# Altium schematic sheet 1_Interface_Charge_RBF.SchDoc
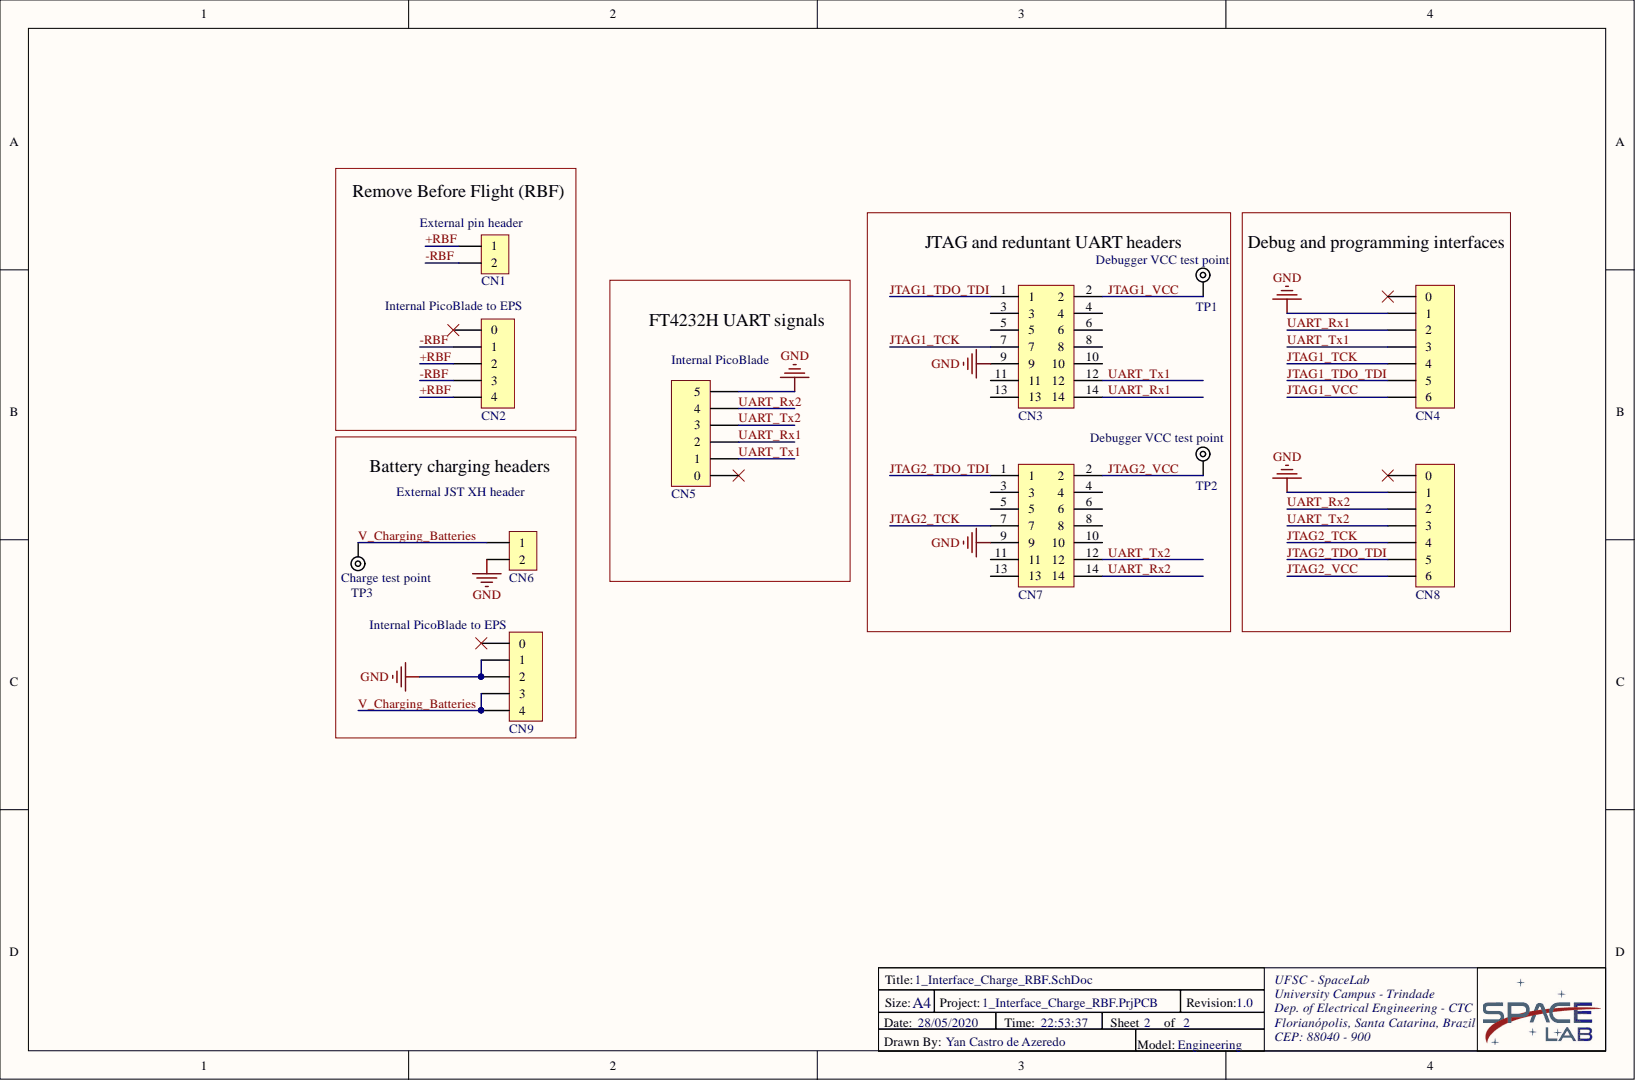
[diagram p0: 1_Interface_Charge_RBF.SchDoc]

## Parts
CN1  M20-9990245  [CONN HEADER VERT 2POS 2.54mm]  (value not drawn)
CN2  0533980471  [PICO BLADE 0533980471]  (value not drawn)
CN3  M20-9980745  [CONN HEADER VERT 14POS 2.54MM]  (value not drawn)
CN4  53398-0671  [PICO BLADE 0533980671]  (value not drawn)
CN5  53398-0571  [PICO BLADE 0533980571]  (value not drawn)
CN6  B2B-XH-A-M(LF)(SN)  [B2B-XH-A-M(LF)(SN)]  (value not drawn)
CN7  M20-9980745  [CONN HEADER VERT 14POS 2.54MM]  (value not drawn)
CN8  53398-0671  [PICO BLADE 0533980671]  (value not drawn)
CN9  0533980471  [PICO BLADE 0533980471]  (value not drawn)
TP1  Debugger VCC test point  [TEST POINT PTH]  (no BOM)
TP2  Debugger VCC test point  [TEST POINT PTH]  (no BOM)
TP3  Charge test point  [TEST POINT PTH]  (no BOM)

## Nets
GND: CN3.9 CN4.1 CN5.5 CN6.2 CN7.9 CN8.1 CN9.1 CN9.2
+RBF: CN1.1 CN2.2 CN2.4
-RBF: CN1.2 CN2.1 CN2.3
JTAG1_TCK: CN3.7 CN4.4
JTAG1_TDO_TDI: CN3.1 CN4.5
JTAG1_VCC: CN3.2 CN4.6 TP1.1
JTAG2_TCK: CN7.7 CN8.4
JTAG2_TDO_TDI: CN7.1 CN8.5
JTAG2_VCC: CN7.2 CN8.6 TP2.1
UART_Rx1: CN3.14 CN4.2 CN5.2
UART_Rx2: CN5.4 CN7.14 CN8.2
UART_Tx1: CN3.12 CN4.3 CN5.1
UART_Tx2: CN5.3 CN7.12 CN8.3
V_Charging_Batteries: CN6.1 CN9.3 CN9.4 TP3.1

Not connected: CN2 0; CN3 3 4 5 6 8 10 11 13; CN4 0; CN5 0; CN7 3 4 5 6 8 10 11 13; CN8 0; CN9 0

## Library data of the parts
CN1 = M20-9990245: M20 HDR, PIN, SIL, VERT, 2W; Manufacturer: Harwin; Connector Type: Male Pin; Contact Resistance: 25mR; Current: 3A; Gender: Male; Insulation Resistance: 10GR; Mating Cycles: 300; Number of Contacts: 2; Pitch: 2.54mm; Voltage: 50V; Voltage Rating (AC): 750V; Case/Package: SIL; Color: Black; Component Kind: Standard; ComponentLink1Description: http://www.farnell.com/datasheets/2368211.pdf?src-supplier=Element14; ComponentLink1URL: http://www.farnell.com/datasheets/2368211.pdf?src-supplier=Element14; ComponentLink2Description: http://uk.rs-online.com/webdocs/1388/0900766b81388728.pdf?src-supplier=RSComponents; ComponentLink2URL: http://uk.rs-online.com/webdocs/1388/0900766b81388728.pdf?src-supplier=RSComponents; ComponentLink3Description: https://cdn.harwin.com/pdfs/Harwin_PC_Connectors_M20.pdf?src-supplier=Digi-Key; ComponentLink3URL: https://cdn.harwin.com/pdfs/Harwin_PC_Connectors_M20.pdf?src-supplier=Digi-Key; ComponentLink4Description: http://www.mouser.com/ds/2/181/Harwin_Product_Catalog_page_206-1134556.pdf?src-supplier=Mouser; ComponentLink4URL: http://www.mouser.com/ds/2/181/Harwin_Product_Catalog_page_206-1134556.pdf?src-supplier=Mouser; Contact Material: Brass,Bronze; Contact Mating Length: 0.24inch; Contact Plating: Gold; Current Rating: 3A; Depth: 2.54mm; Height: 0.12inch; Housing Color: Black; Housing Material: Nylon; Lead Pitch: 2.54mm; Length: 5.08mm; Manufacturer Part Number: M20-9990245; Max Current Rating: 3A; Max Operating Temperature: 105°C; Min Operating Temperature: -40°C; Mount: Through Hole; Number of Poles: 2; Number of Positions: 2; Number of Rows: 1; Orientation: Straight; Package Quantity: 1000; Packaging: Bag; Pricing 1: 1=0.15, 10=0.136, 100=0.0841, 500=0.0696, 1000=0.0609, 5000=0.0522, 10000=0.05075 (USD); Pricing 2: 1=0.14, 10=0.083, 100=0.069, 1000=0.06, 2000=0.052, 10000=0.05 (USD); Radiation Hardening: No; REACH SVHC: No SVHC; Stock 1: 27298; Stock 2: 26881; Supplier 1: Digi-Key; Supplier 2: Mouser; Supplier Part Number 1: 952-2261-ND; Supplier Part Number 2: 855-M20-9990245; Termination: Solder; Weight: 0.25lb; Width: 0.25inch; Working Voltage: 750V; Library Name: IB_floripasat2.SchLib
CN2 CN9 = 0533980471: CONN HEADER SMD 4POS 1.25MM; Manufacturer: Molex; N of Positions: 4; Component Kind: Standard; Mount Type: SMD; Pitch: 1.25mm; Value: 0533980471; Library Name: IB_floripasat2.SchLib
CN3 CN7 = M20-9980745: Headers & Wire Housings 07+07 DIL VERTICAL PIN HEADER GOLD HT; Manufacturer: Harwin; Connector Type: Male Pin; Contact Resistance: 25mR; Gender: Male; Max Current Rating: 3A; Number of Contacts: 14; Pitch: 2.54mm; Row Spacing: 0.1inch; Color: Black; Component Kind: Standard; ComponentLink1Description: https://www.verical.com/server-webapp/html/getDatasheet?partID=468550&src-supplier=Verical; ComponentLink1URL: https://www.verical.com/server-webapp/html/getDatasheet?partID=468550&src-supplier=Verical; ComponentLink2Description: http://www.harwin.com/include/downloads/drawings/M20-998.PDF?src-supplier=Element14; ComponentLink2URL: http://www.harwin.com/include/downloads/drawings/M20-998.PDF?src-supplier=Element14; ComponentLink3Description: http://www.mouser.com/ds/2/181/M20-998-351176.pdf?src-supplier=Mouser; ComponentLink3URL: http://www.mouser.com/ds/2/181/M20-998-351176.pdf?src-supplier=Mouser; Contact Material: Brass; Contact Mating Length: 0.24inch; Contact Plating: Gold; Depth: 5.08mm; Housing Color: Black; Housing Material: Nylon; Length: 17.78mm; Manufacturer Part Number: M20-9980745; Max Operating Temperature: 105°C; Min Operating Temperature: -40°C; Mount: Through Hole; Number of Positions: 14; Number of Rows: 2; Orientation: Straight; Package Quantity: 500; Packaging: Bag; Pricing 1: 1=0.54, 10=0.51, 100=0.391, 500=0.34, 1000=0.2805, 5000=0.2465, 10000=0.2295 (USD); Pricing 2: 1=0.54, 10=0.51, 100=0.387, 500=0.34, 1000=0.28, 2500=0.246 (USD); Radiation Hardening: No; REACH SVHC: No SVHC; Stock 1: 15564; Stock 2: 1790; Supplier 1: Digi-Key; Supplier 2: Mouser; Supplier Part Number 1: 952-1932-ND; Supplier Part Number 2: 855-M20-9980745; Termination: Solder; Library Name: IB_floripasat2.SchLib
CN4 CN8 = 53398-0671: 1.25mm Pitch PicoBlade™ Header, Surface Mount, Vertical, 6 Circuits; Manufacturer: Molex; Body Plating: Tin; Contact Resistance: 20mR; Current Rating: 1A; Gender: Male; Glow Wire Compliant: Non-compliant; Insulation Resistance: 100MR; Number of Circuits: 6; Voltage Rating: 125V; Color: Natural; Component Kind: Standard; ComponentLink1Description: https://www.molex.com/pdm_docs/sd/533980671_sd.pdf?src-supplier=Digi-Key; ComponentLink1URL: https://www.molex.com/pdm_docs/sd/533980671_sd.pdf?src-supplier=Digi-Key; Contact Material: Bronze,Tin; Contact Plating: Tin; Flammability Rating: UL94 V-0; Housing Color: Natural; Housing Material: Nylon; Lead Free: Lead Free; Lead Pitch: 1.25mm; Manufacturer Part Number: 53398-0671; Max Operating Temperature: 85°C; Min Operating Temperature: -40°C; Mount: Surface Mount; Number of Contacts: 6; Number of Positions: 6; Number of Rows: 1; Orientation: Vertical; Package Quantity: 1000; Packaging: Tape and Reel; Pitch: 1.25mm; Pricing 1: 1=1.39, 10=1.26, 100=1.0802, 500=0.90014 (USD); Pricing 2: 1=1.15, 10=1.01, 100=0.864, 500=0.727, 1000=0.427, 2000=0.374 (USD); Radiation Hardening: No; REACH SVHC: Unknown; Stock 1: 21086; Stock 2: 26; Supplier 1: Digi-Key; Supplier 2: Mouser; Supplier Part Number 1: WM7610DKR-ND; Supplier Part Number 2: 538-53398-0671; Termination: Solder; Voltage Rating (AC): 125V; Voltage Rating (DC): 125V; Working Voltage: 125V; Library Name: IB_floripasat2.SchLib
CN5 = 53398-0571: Wire-To-Board Connector, Vertical, PicoBlade 53398 Series, Surface Mount, Header, 5, 1.25 mm; Manufacturer: Molex; Body Plating: Tin; Contact Resistance: 20mR; Current Rating: 1A; Gender: Male; Glow Wire Compliant: Non-compliant; Insulation Resistance: 100MR; Max Voltage Rating (AC): 125V; Number of Circuits: 5; Pitch: 1.25mm; Color: Natural; Component Kind: Standard; ComponentLink1Description: http://www.molex.com/webdocs/datasheets/pdf/en-us/0533980571_PCB_HEADERS.pdf?src-supplier=Avnet+Europe; ComponentLink1URL: http://www.molex.com/webdocs/datasheets/pdf/en-us/0533980571_PCB_HEADERS.pdf?src-supplier=Avnet+Europe; ComponentLink2Description: http://www.farnell.com/datasheets/2697810.pdf?src-supplier=Newark; ComponentLink2URL: http://www.farnell.com/datasheets/2697810.pdf?src-supplier=Newark; ComponentLink3Description: https://www.distrelec.biz/Web/Downloads/46/_e/gf116833-116846_e.pdf?mime=application%2Fpdf&src-supplier=Distrelec; ComponentLink3URL: https://www.distrelec.biz/Web/Downloads/46/_e/gf116833-116846_e.pdf?mime=application%2Fpdf&src-supplier=Distrelec; ComponentLink4Description: http://www.farnell.com/datasheets/1632115.pdf?src-supplier=Element14; ComponentLink4URL: http://www.farnell.com/datasheets/1632115.pdf?src-supplier=Element14; ComponentLink5Description: http://uk.rs-online.com/webdocs/078f/0900766b8078fc92.pdf?src-supplier=RSComponents; ComponentLink5URL: http://uk.rs-online.com/webdocs/078f/0900766b8078fc92.pdf?src-supplier=RSComponents; ComponentLink6Description: http://www.farnell.com/datasheets/1867377.pdf?src-supplier=Element14; ComponentLink6URL: http://www.farnell.com/datasheets/1867377.pdf?src-supplier=Element14; ComponentLink7Description: http://www.farnell.com/datasheets/1626108.pdf?src-supplier=Element14; ComponentLink7URL: http://www.farnell.com/datasheets/1626108.pdf?src-supplier=Element14; ComponentLink8Description: http://www.mouser.com/ds/2/276/0533980571_PCB_HEADERS-146486.pdf?src-supplier=Mouser; ComponentLink8URL: http://www.mouser.com/ds/2/276/0533980571_PCB_HEADERS-146486.pdf?src-supplier=Mouser; Contact Gender: Male; Contact Material: Bronze,Tin,Brass; Contact Plating: Tin; Flammability Rating: UL94 V-0; Housing Color: Natural; Housing Material: Nylon; Lead Free: Lead Free; Lead Pitch: 1.25mm; Manufacturer Part Number: 53398-0571; Max Operating Temperature: 85°C; Min Operating Temperature: -40°C; Mount: Surface Mount; Number of Contacts: 5; Number of Positions: 5; Number of Rows: 1; Orientation: Vertical; Package Quantity: 1000; Packaging: Tape and Reel; Pricing 1: 1=1.32, 10=1.159, 100=0.9999, 500=0.81814 (USD); Pricing 2: 1=1.32, 10=1.15, 100=0.999, 500=0.818, 1000=0.389, 2000=0.342 (USD); Radiation Hardening: No; REACH SVHC: Unknown; Stock 1: 427; Stock 2: 35606; Supplier 1: Digi-Key; Supplier 2: Mouser; Supplier Part Number 1: WM7609CT-ND; Supplier Part Number 2: 538-53398-0571; Termination: Solder; Voltage Rating: 125V; Voltage Rating (AC): 125V; Voltage Rating (DC): 125V; Working Voltage: 125V; Library Name: IB_floripasat2.SchLib
CN6 = B2B-XH-A-M(LF)(SN): CONN HEADER TOP 2POS 2.5MM GRN; Manufacturer: JST; Connector Type: Male Pin; Number of Positions: 2; Pitch: 0.098inch; Color: Natural; Component Kind: Standard; ComponentLink1Description: http://www.jst-mfg.com/product/pdf/eng/eXH.pdf; ComponentLink1URL: http://www.jst-mfg.com/product/pdf/eng/eXH.pdf; Contact Plating: Tin; Fastening Type: Detent; Manufacturer Part Number: B2B-XH-A-M(LF)(SN); Mount: Through Hole; Number of Rows: 1; Package Quantity: 1000; Packaging: Bulk; Pricing 1: 1=0.17, 10=0.157, 100=0.0966, 500=0.07998, 1000=0.06999, 5000=0.05999, 10000=0.05832 (USD); Stock 1: 5564; Supplier 1: Digi-Key; Supplier Part Number 1: 455-2879-ND; Termination: Press-Fit; Library Name: IB_floripasat2.SchLib
TP1-TP3 = Test Point PTH: TEST POINT PAD; Library Name: IB_floripasat2.SchLib
